# Altium schematic sheet Sheet1.SchDoc
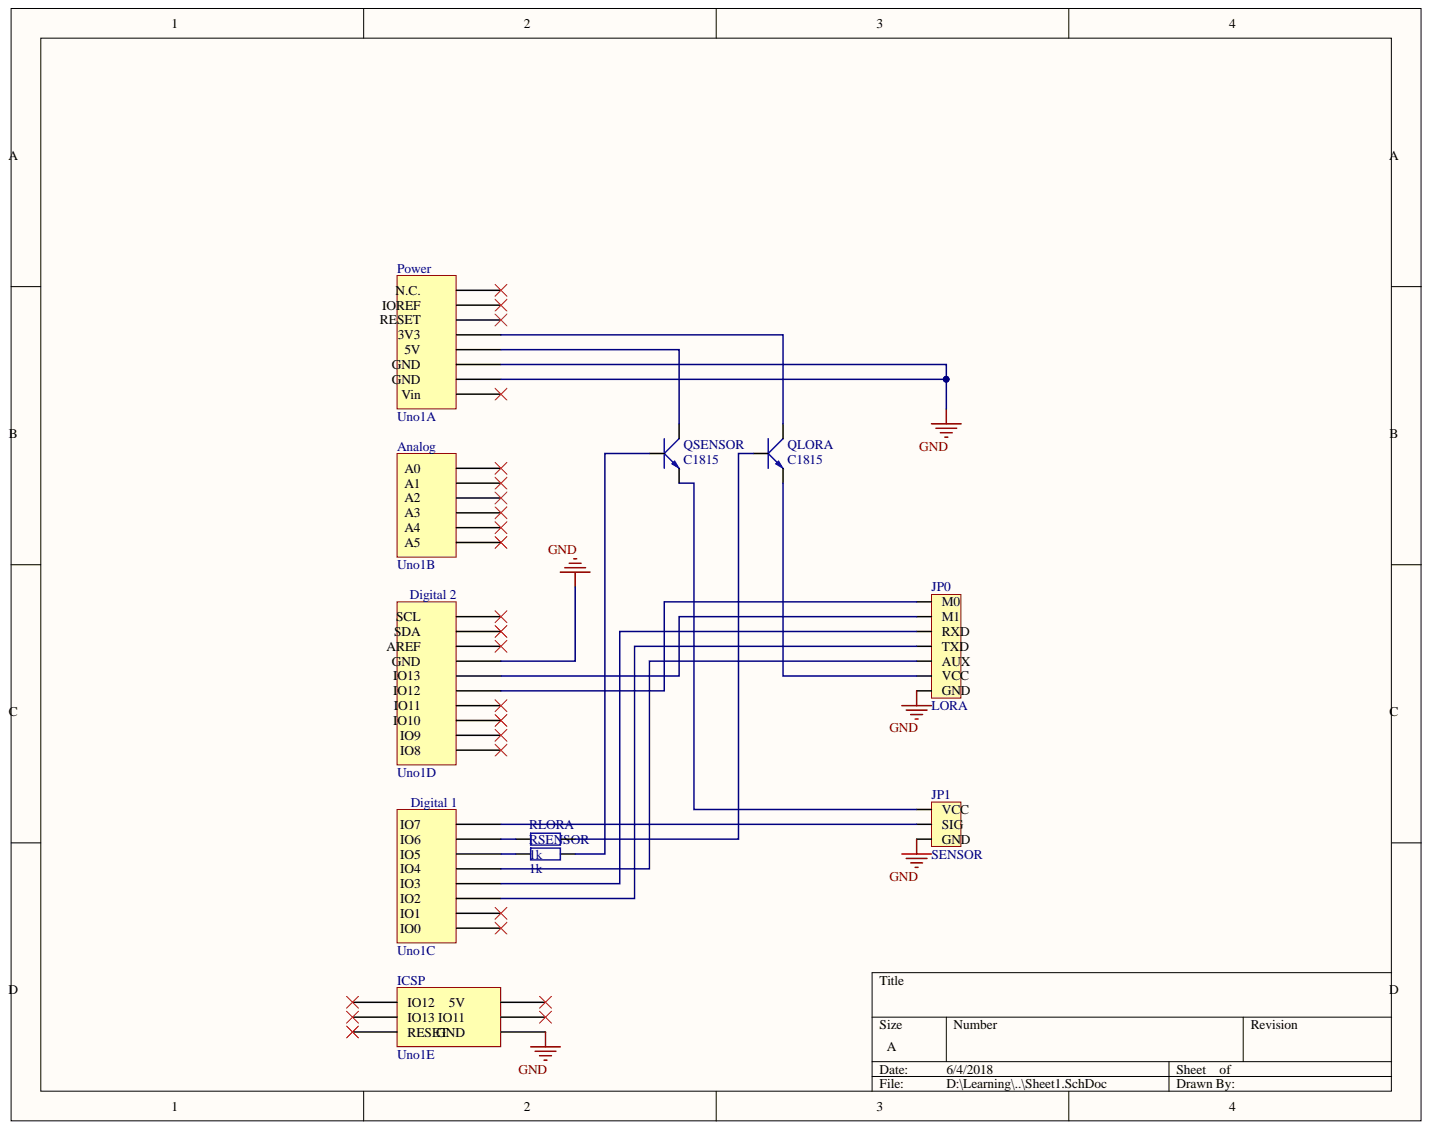
[diagram p0: Sheet1.SchDoc]

## Parts
JP0  LORA  [CON7]
JP1  SENSOR  [CON3]
QLORA  C1815  [QTO-92]
QSENSOR  C1815  [QTO-92]
RLORA  1k  [R1/4W]
RSENSOR  1k  [R1/4W]
Uno1  Arduino Uno  [Arduino Uno Adapter]  (value not drawn)  (parts A,B,C,D,E)

## Nets
GND: JP0.7 JP1.3 Uno1.GND1 Uno1.GND2 Uno1.GND3 Uno1.ICSP6
NetJP0_1: JP0.1(M0) Uno1.12
NetJP0_2: JP0.2(M1) Uno1.13
NetJP0_3: JP0.3 Uno1.3
NetJP0_4: JP0.4 Uno1.2
NetJP0_5: JP0.5 Uno1.4
NetJP0_6: JP0.6 QLORA.1
NetJP1_1: JP1.1 QSENSOR.1
NetJP1_2: JP1.2 Uno1.7
NetQLORA_2: QLORA.2 Uno1.3V3
NetQLORA_3: QLORA.3 RLORA.2
NetQSENSOR_2: QSENSOR.2 Uno1.5V
NetQSENSOR_3: QSENSOR.3 RSENSOR.2
NetRLORA_1: RLORA.1 Uno1.6
NetRSENSOR_1: RSENSOR.1 Uno1.5

Not connected: Uno1 0 1 8 9 10 11 A0 A1 A2 A3 A4 A5 AREF ICSP1(IO12) ICSP2 ICSP3 ICSP4 ICSP5(RESET) IOREF NC RESET SCL SDA Vin

## Library data of the parts
JP0 = Con7: Connector 7; Component Kind: Standard; Value: Connector 7; Library Name: syhaunguyen.IntLib
JP1 = Con3: Connector 3; Component Kind: Standard; Value: Connector 3; Library Name: syhaunguyen.IntLib
QLORA QSENSOR = C1815: NPN 600mW, ECB; : C828; : C2383; Component Kind: Standard; Value: C1815; Library Name: syhaunguyen.IntLib
RLORA RSENSOR = R1/4W: Resistor 1/4W; Value: R1/4W - 1K; Component Kind: Standard; Library Name: syhaunguyen.IntLib
Uno1 = Arduino Uno: Component Kind: Standard; Library Name: Arduino.SchLib
